# Altium schematic sheet HF_TUNER.SchDoc
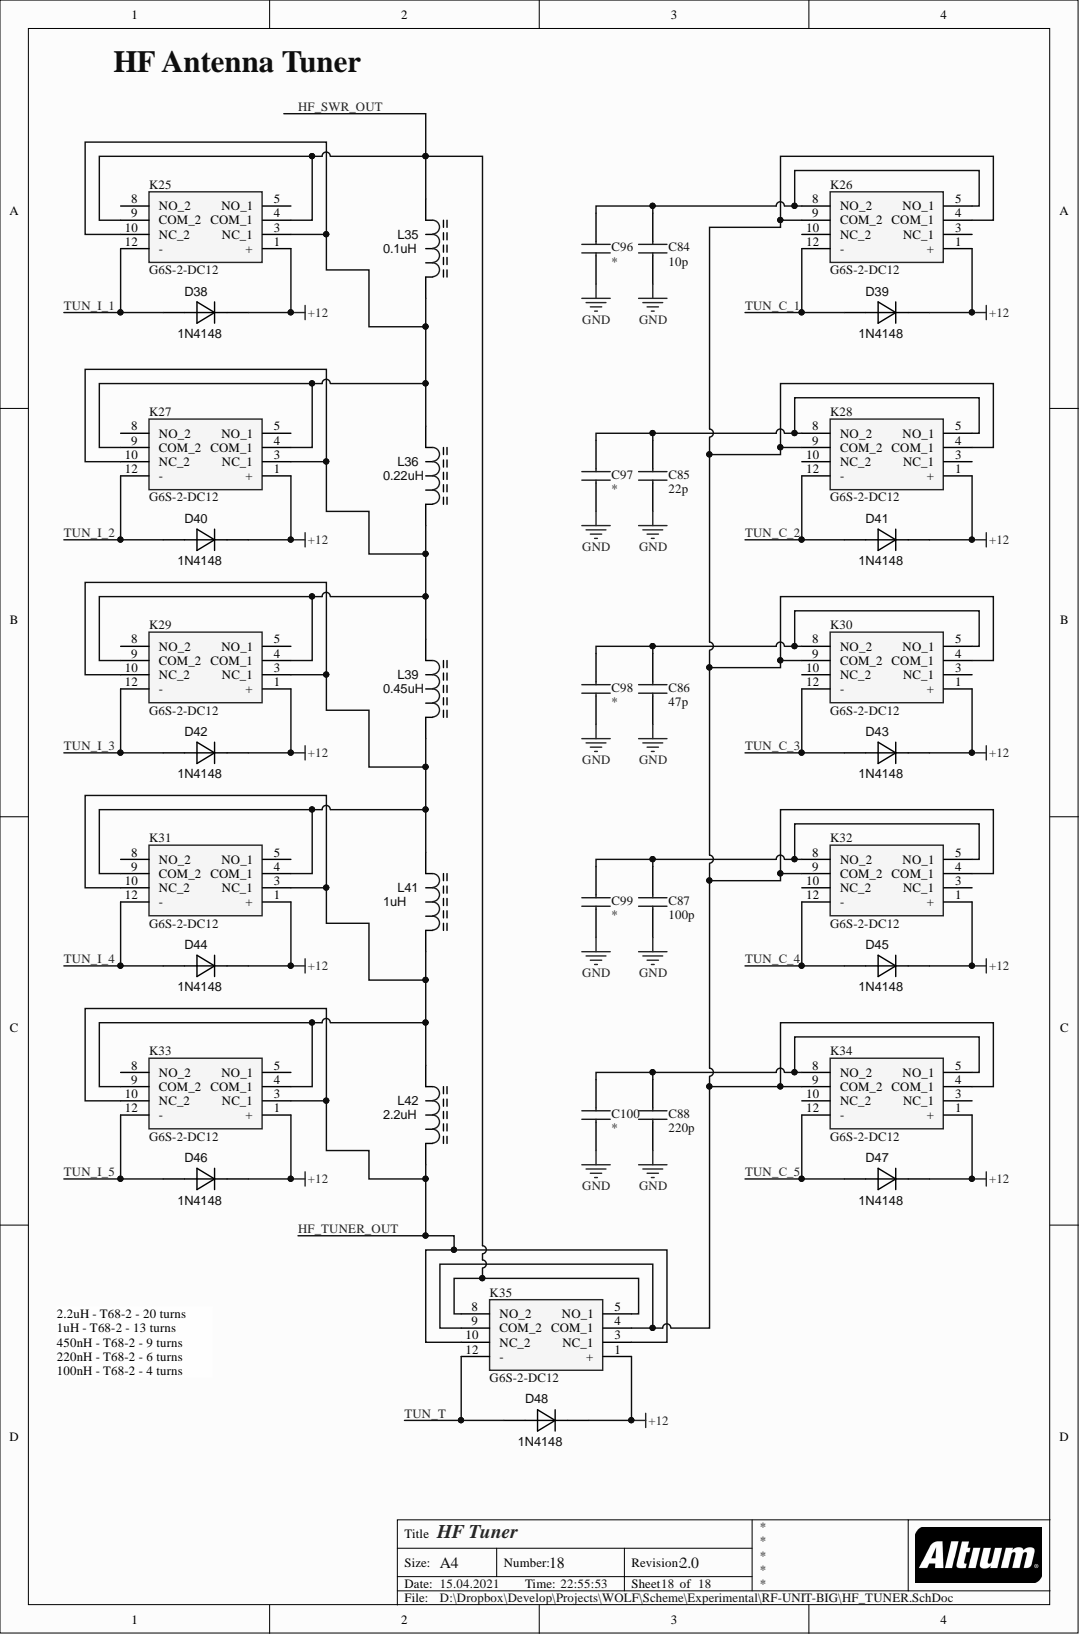
[diagram p0: HF_TUNER.SchDoc]

## Parts
C84  10p  [B32620A0682J000]
C85  22p  [B32620A0682J000]
C86  47p  [B32620A0682J000]
C87  100p  [B32620A0682J000]
C88  220p  [B32620A0682J000]
C96  (no value)  [CAP_1206]
C97  (no value)  [CAP_1206]
C98  (no value)  [CAP_1206]
C99  (no value)  [CAP_1206]
C100  (no value)  [CAP_1206]
D38  1N4148  [SS14]
D39  1N4148  [SS14]
D40  1N4148  [SS14]
D41  1N4148  [SS14]
D42  1N4148  [SS14]
D43  1N4148  [SS14]
D44  1N4148  [SS14]
D45  1N4148  [SS14]
D46  1N4148  [SS14]
D47  1N4148  [SS14]
D48  1N4148  [SS14]
K25  G6S-2-DC12  [G6S2DC12]
K26  G6S-2-DC12  [G6S2DC12]
K27  G6S-2-DC12  [G6S2DC12]
K28  G6S-2-DC12  [G6S2DC12]
K29  G6S-2-DC12  [G6S2DC12]
K30  G6S-2-DC12  [G6S2DC12]
K31  G6S-2-DC12  [G6S2DC12]
K32  G6S-2-DC12  [G6S2DC12]
K33  G6S-2-DC12  [G6S2DC12]
K34  G6S-2-DC12  [G6S2DC12]
K35  G6S-2-DC12  [G6S2DC12]
L35  0.1uH  [IND_FERRITE_CORE_1]
L36  0.22uH  [IND_FERRITE_CORE_1]
L39  0.45uH  [IND_FERRITE_CORE_1]
L41  1uH  [IND_FERRITE_CORE_1]
L42  2.2uH  [IND_FERRITE_CORE_1]

## Nets
+12: D38.1 D39.1 D40.1 D41.1 D42.1 D43.1 D44.1 D45.1 D46.1 D47.1 D48.1 K25.1(+) K26.1(+) K27.1(+) K28.1(+) K29.1(+) K30.1(+) K31.1(+) K32.1(+) K33.1(+) K34.1(+) K35.1(+)
GND: C84.1 C85.1 C86.1 C87.1 C88.1 C96.1 C97.1 C98.1 C99.1 C100.1
HF_SWR_OUT: K25.4(COM_1) K25.9(COM_2) K35.5(NO_1) K35.8(NO_2) L35.1
HF_TUNER_OUT: K33.3(NC_1) K33.10(NC_2) K35.3(NC_1) K35.10(NC_2) L42.2
TUN_I_1: D38.2 K25.12(-)
TUN_I_2: D40.2 K27.12(-)
TUN_I_3: D42.2 K29.12(-)
TUN_I_4: D44.2 K31.12(-)
TUN_I_5: D46.2 K33.12(-)
TUN_T: D48.2 K35.12(-)
TUN_С_1: D39.2 K26.12(-)
TUN_С_2: D41.2 K28.12(-)
TUN_С_3: D43.2 K30.12(-)
TUN_С_4: D45.2 K32.12(-)
TUN_С_5: D47.2 K34.12(-)
NetC84_2: C84.2 C96.2 K26.5(NO_1) K26.8(NO_2)
NetC85_2: C85.2 C97.2 K28.5(NO_1) K28.8(NO_2)
NetC86_2: C86.2 C98.2 K30.5(NO_1) K30.8(NO_2)
NetC87_2: C87.2 C99.2 K32.5(NO_1) K32.8(NO_2)
NetC88_2: C88.2 C100.2 K34.5(NO_1) K34.8(NO_2)
NetK25_3: K25.3(NC_1) K25.10(NC_2) K27.4(COM_1) K27.9(COM_2) L35.2 L36.1
NetK26_4: K26.4(COM_1) K26.9(COM_2) K28.4(COM_1) K28.9(COM_2) K30.4(COM_1) K30.9(COM_2) K32.4(COM_1) K32.9(COM_2) K34.4(COM_1) K34.9(COM_2) K35.4(COM_1) K35.9(COM_2)
NetK27_3: K27.3(NC_1) K27.10(NC_2) K29.4(COM_1) K29.9(COM_2) L36.2 L39.1
NetK29_3: K29.3(NC_1) K29.10(NC_2) K31.4(COM_1) K31.9(COM_2) L39.2 L41.1
NetK31_3: K31.3(NC_1) K31.10(NC_2) K33.4(COM_1) K33.9(COM_2) L41.2 L42.1

Not connected: K25 5(NO_1) 8(NO_2); K26 3(NC_1) 10(NC_2); K27 5(NO_1) 8(NO_2); K28 3(NC_1) 10(NC_2); K29 5(NO_1) 8(NO_2); K30 3(NC_1) 10(NC_2); K31 5(NO_1) 8(NO_2); K32 3(NC_1) 10(NC_2); K33 5(NO_1) 8(NO_2); K34 3(NC_1) 10(NC_2)

## Library data of the parts
C84-C88 = B32620A0682J000: Capacitor; Manufacturer_Name: TDK; Component Kind: Standard; Datasheet Link: https://www.tdk-electronics.tdk.com/inf/20/20/db/fc_2009/MKP_B32620_621.pdf; Height: 12mm; Manufacturer_Part_Number: B32620A0682J000; Mouser Part Number: 871-B32620A682J; Mouser Price/Stock: https://www.mouser.com/Search/Refine.aspx?Keyword=871-B32620A682J
C96-C100 = B32620A0682J000: Capacitor NP0 HiVolt; Manufacturer_Name: TDK; Component Kind: Standard; Datasheet Link: https://www.tdk-electronics.tdk.com/inf/20/20/db/fc_2009/MKP_B32620_621.pdf; Height: 12mm; Manufacturer_Part_Number: B32620A0682J000; Mouser Part Number: 871-B32620A682J; Mouser Price/Stock: https://www.mouser.com/Search/Refine.aspx?Keyword=871-B32620A682J
K25-K35 = G6S-2-DC12: Relay or Contactor; Manufacturer_Name: Omron Electronics; Component Kind: Standard; Datasheet Link: https://componentsearchengine.com/Datasheets/1/G6S-2-DC12.pdf; Height: 9.4mm; Manufacturer_Part_Number: G6S-2-DC12; Mouser Part Number: 653-G6S-2-DC12A; Mouser Price/Stock: https://www.mouser.co.uk/ProductDetail/Omron-Electronics/G6S-2-DC12/?qs=QGk6feVlqMLN9Ouv0QsFzA%3D%3D
L35 L36 L39 L41 L42 = IND_FERRITE_CORE: Inductor; Component Kind: Standard
D38-D48 = 1N4148: Component Kind: Standard; Description: 1N4148; Library Name: RF-UNIT-BIG.SchLib
